# Altium schematic sheet 12_CONSOLE.SchDoc(12_CONSOLE)
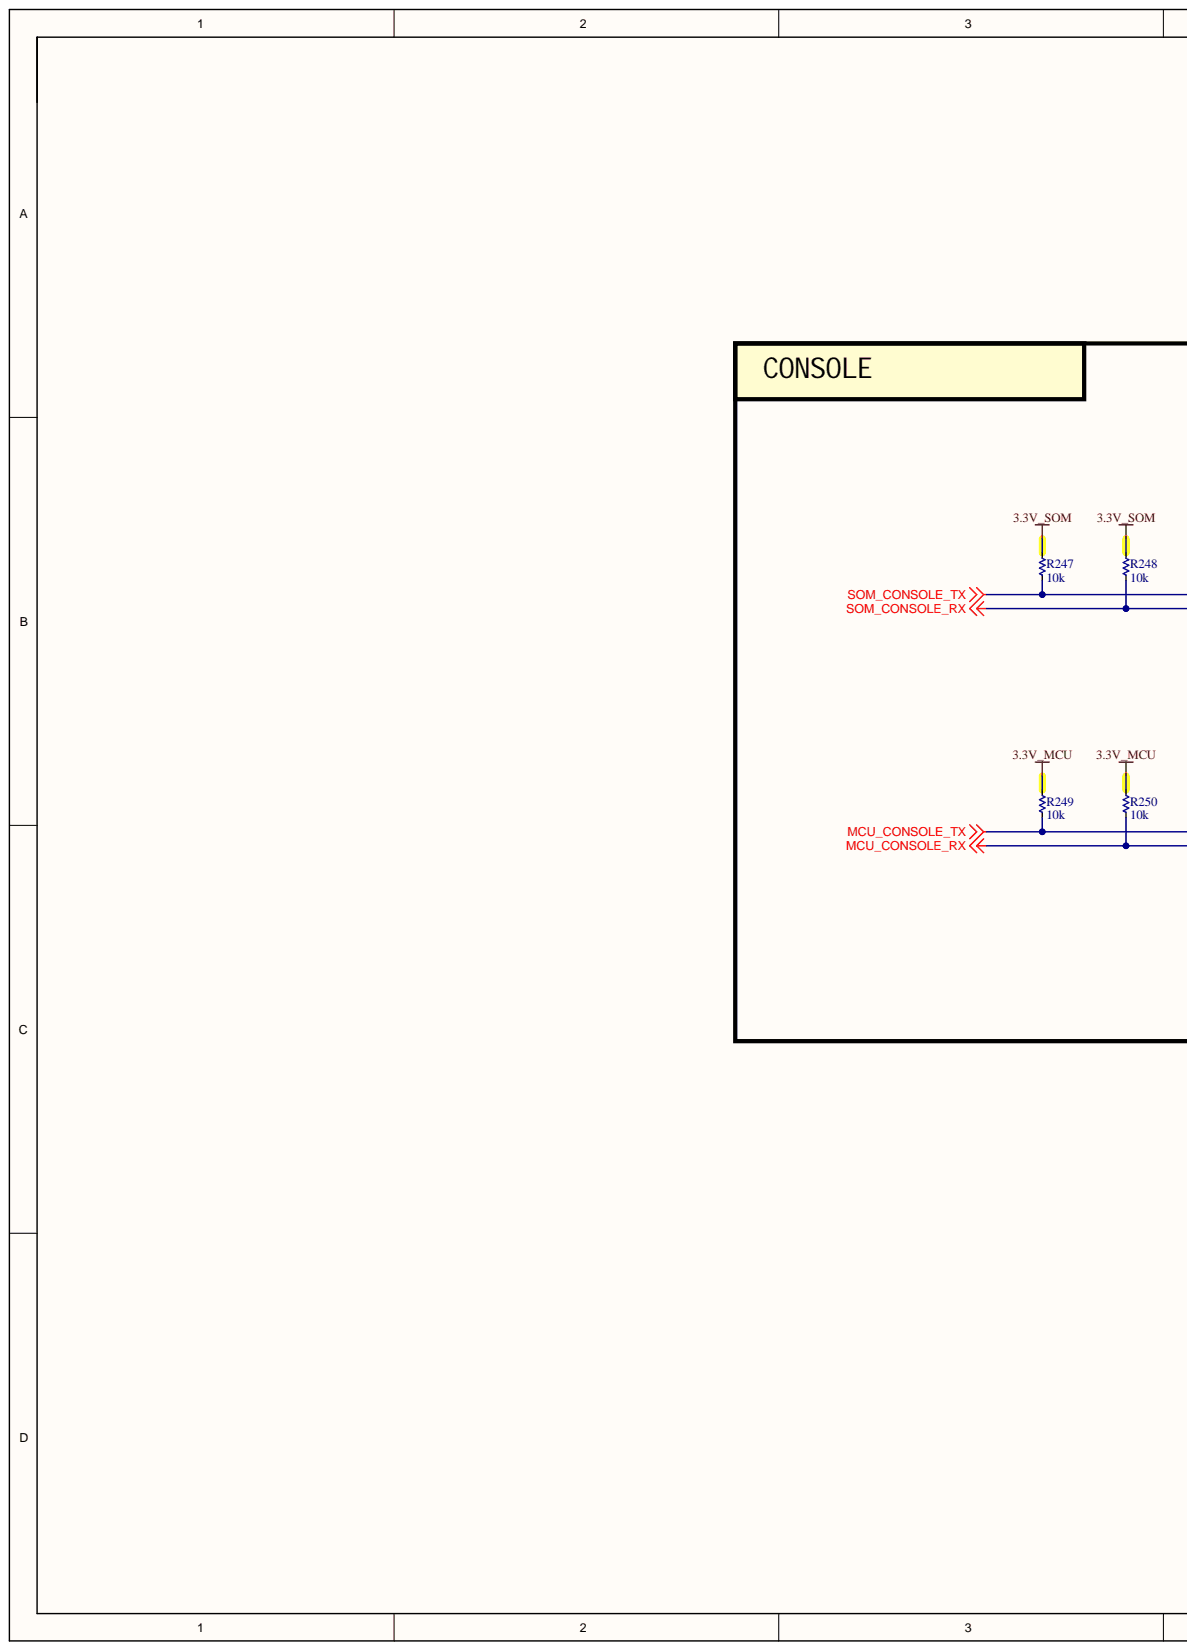
[diagram p0: 12_CONSOLE.SchDoc, left]
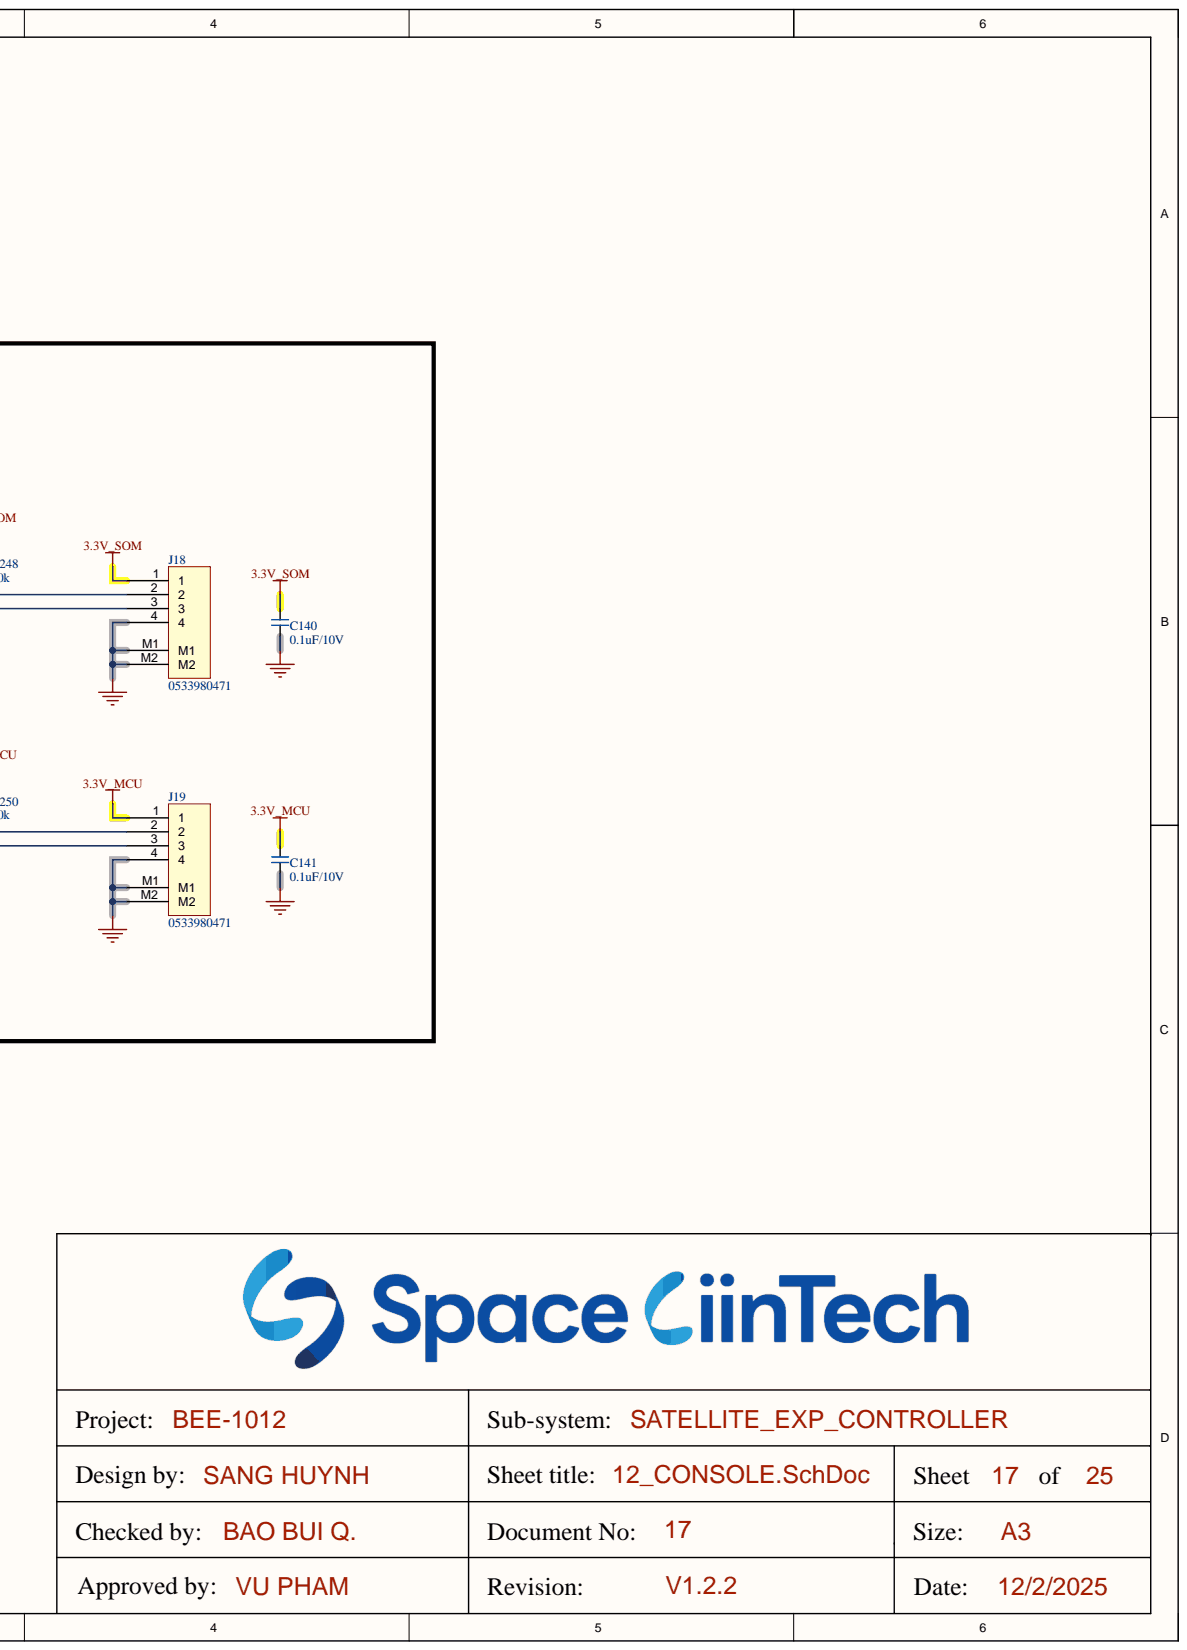
[diagram p1: 12_CONSOLE.SchDoc, right]

## Parts
C140  0.1uF/10V  [CAP_C_1005X60]
C141  0.1uF/10V  [CAP_C_1005X60]
J18  0533980471  [MOLEX_0533980471]
J19  0533980471  [MOLEX_0533980471]
R247  10k  [RES_C_1005X40]
R248  10k  [RES_C_1005X40]
R249  10k  [RES_C_1005X40]
R250  10k  [RES_C_1005X40]

## Nets
3.3V_MCU: C141.2 J19.1 R249.2 R250.2
3.3V_SOM: C140.2 J18.1 R247.2 R248.2
GND: C140.1 C141.1 J18.4 J18.M1 J18.M2 J19.4 J19.M1 J19.M2
MCU_CONSOLE_RX: J19.3 R250.1
MCU_CONSOLE_TX: J19.2 R249.1
SOM_CONSOLE_RX: J18.3 R248.1
SOM_CONSOLE_TX: J18.2 R247.1

## Library data of the parts
C140 C141 = _CAP_C_2: CAP CHIP; Component Kind: Standard; Library Name: LABLIB.SchLib
J18 J19 = Header 4x1_2M: Component Kind: Standard; Library Name: LABLIB.SchLib
R247-R250 = _RES_B_2: RES; Component Kind: Standard; Library Name: LABLIB.SchLib
